# Altium schematic sheet RTC_Breakout_SP24.SchDoc
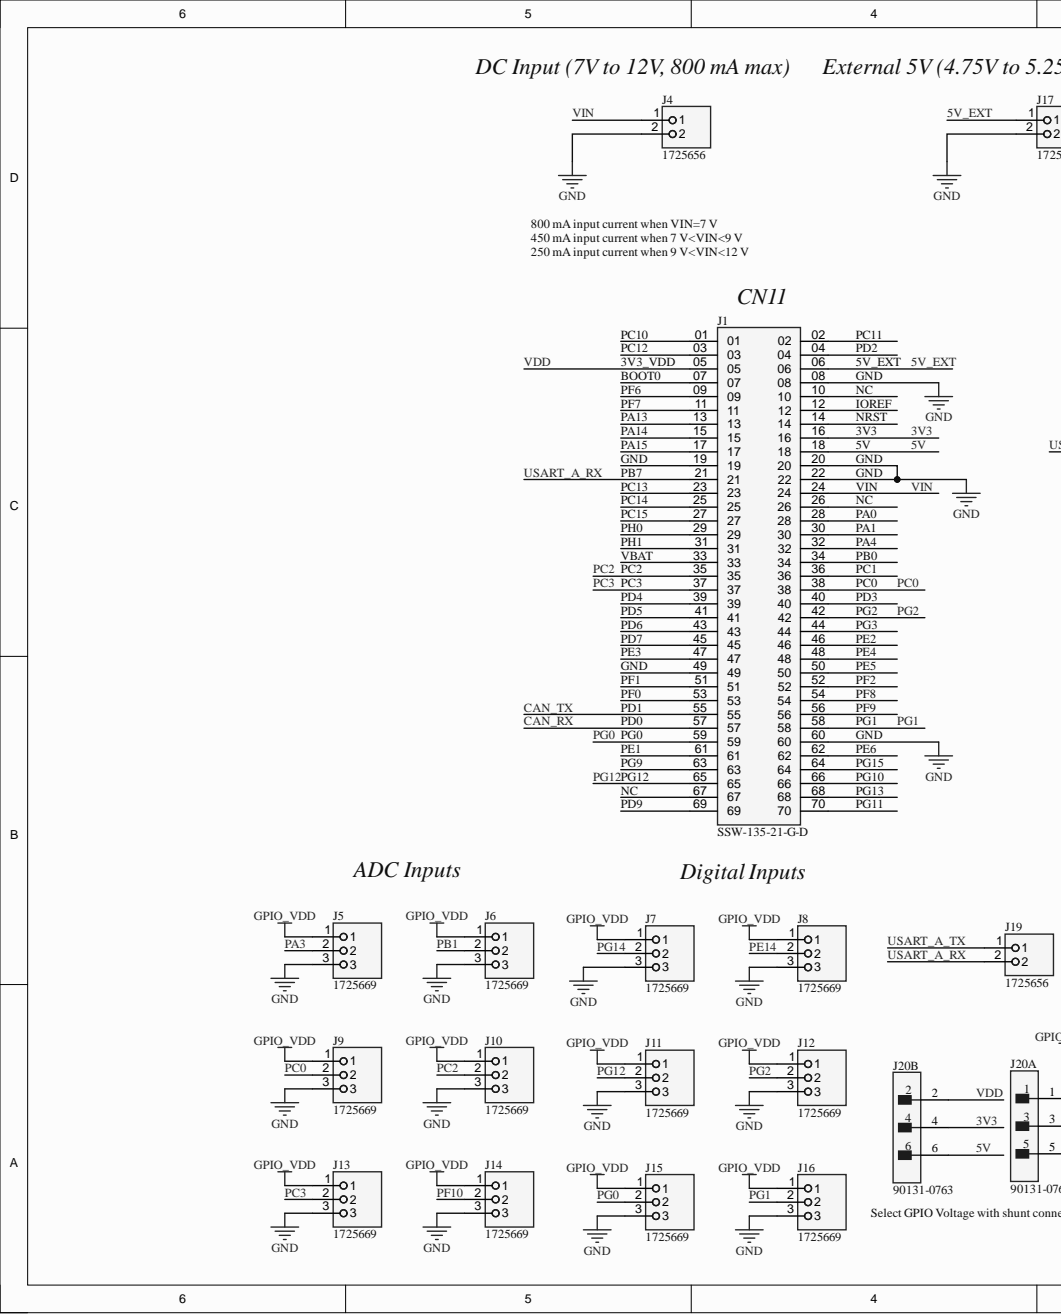
[diagram p0: RTC_Breakout_SP24.SchDoc, left]
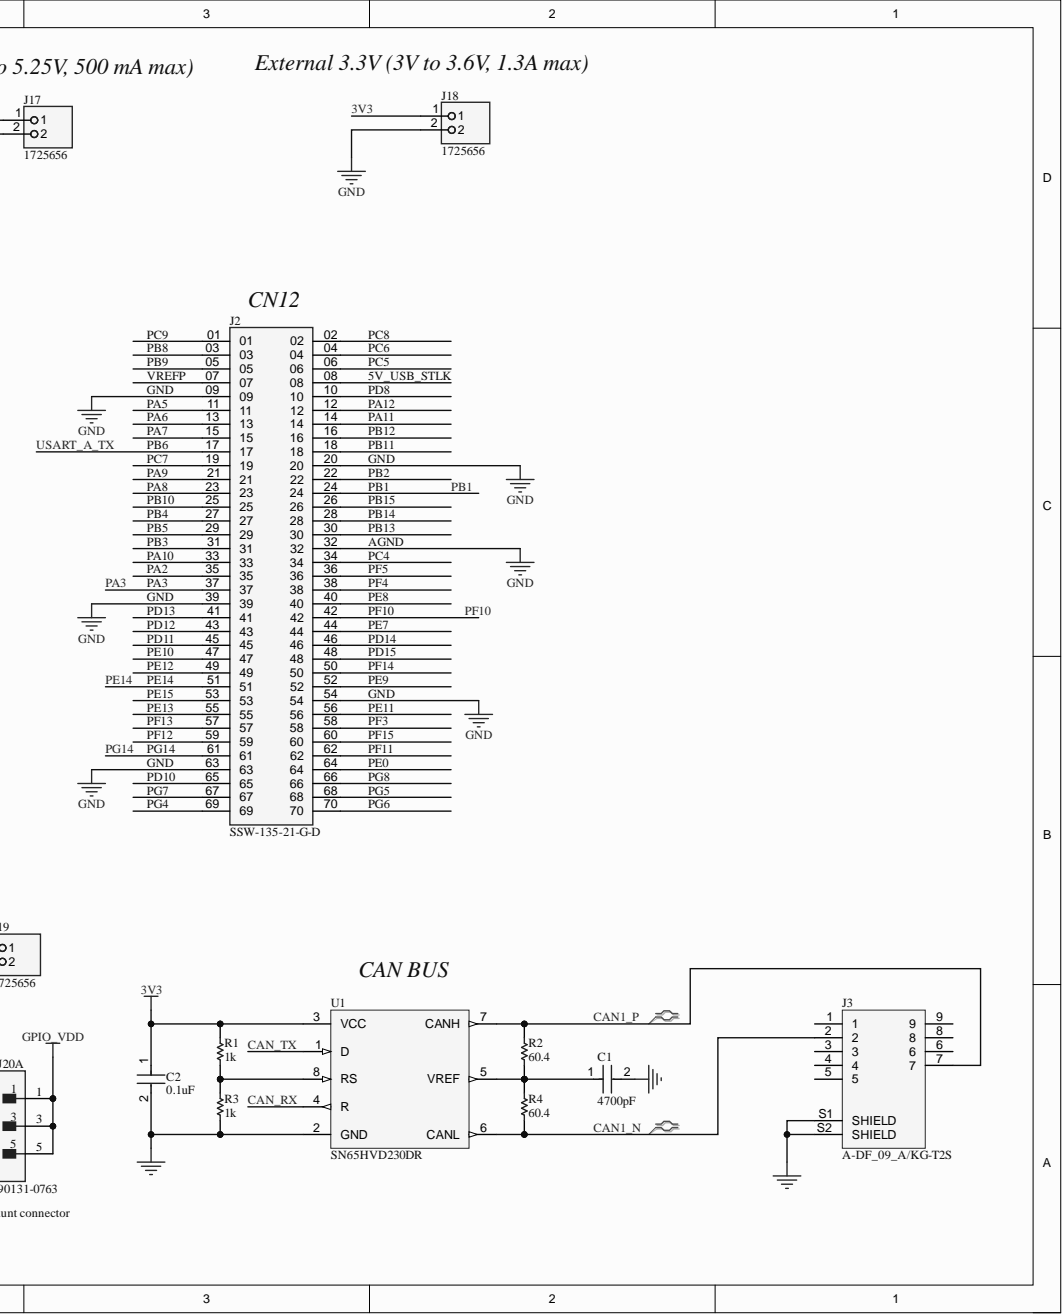
[diagram p1: RTC_Breakout_SP24.SchDoc, right]

## Parts
C1  4700pF  [FP-CC0805-0_85-MFG]
C2  0.1uF  [FP-CC0805-0_85-MFG]
J1  SSW-135-21-G-D  [SAMTEC_SSW-135-21-G-D]
J2  SSW-135-21-G-D  [SAMTEC_SSW-135-21-G-D]
J3  A-DF_09_A/KG-T2S  [ASSMANN_A-DF09A/KG-T2S]
J4  1725656  [PHOENIX_1725656]
J5  1725669  [PHOENIX_1725669]
J6  1725669  [PHOENIX_1725669]
J7  1725669  [PHOENIX_1725669]
J8  1725669  [PHOENIX_1725669]
J9  1725669  [PHOENIX_1725669]
J10  1725669  [PHOENIX_1725669]
J11  1725669  [PHOENIX_1725669]
J12  1725669  [PHOENIX_1725669]
J13  1725669  [PHOENIX_1725669]
J14  1725669  [PHOENIX_1725669]
J15  1725669  [PHOENIX_1725669]
J16  1725669  [PHOENIX_1725669]
J17  1725656  [PHOENIX_1725656]
J18  1725656  [PHOENIX_1725656]
J19  1725656  [PHOENIX_1725656]
J20  90131-0763  [FP-90131-0763-MFG]  (parts A,B)
R1  1k  [RESC2013X60X35ML10T20]
R2  60.4  [RESC2013X60X35LL10T20]
R3  1k  [RESC2013X60X35ML10T20]
R4  60.4  [RESC2013X60X35LL10T20]
U1  SN65HVD230DR  [SOIC127P599X175-8N]

## Nets
GND: C1.2 C2.2 J1.08 J1.20 J1.22 J1.60 J2.09 J2.20 J2.32 J2.39 J2.54 J2.63 J3.S1(SHIELD) J3.S2(SHIELD) J4.2 J5.3 J6.3 J7.3 J8.3 J9.3 J10.3 J11.3 J12.3 J13.3 J14.3 J15.3 J16.3 J17.2 J18.2 R3.1 U1.2(GND)
GPIO_VDD: J5.1 J6.1 J7.1 J8.1 J9.1 J10.1 J11.1 J12.1 J13.1 J14.1 J15.1 J16.1 J20.1 J20.3 J20.5
3V3: C2.1 J1.16 J18.1 J20.4 R1.2 U1.3(VCC)
5V: J1.18 J20.6
5V_EXT: J1.06 J17.1
CAN1_N: J3.2 R4.1 U1.6(CANL)
CAN1_P: J3.7 R2.2 U1.7(CANH)
CAN_RX: J1.57 U1.4(R)
CAN_TX: J1.55 U1.1(D)
PA3: J2.37 J5.2
PB1: J2.24 J6.2
PC0: J1.38 J9.2
PC2: J1.35 J10.2
PC3: J1.37 J13.2
PE14: J2.51 J8.2
PF10: J2.42 J14.2
PG0: J1.59 J15.2
PG1: J1.58 J16.2
PG2: J1.42 J12.2
PG12: J1.65 J11.2
PG14: J2.61 J7.2
USART_A_RX: J1.21 J19.2
USART_A_TX: J2.17 J19.1
VDD: J1.05 J20.2
VIN: J1.24 J4.1
NetC1_1: C1.1 R2.1 R4.2 U1.5(VREF)
NetR1_1: R1.1 R3.2 U1.8(RS)

Not connected: J1 01 02 03 04 07 09 10 11 12 13 14 15 17 19 23 25 26 27 28 29 30 31 32 33 34 36 39 40 41 43 44 45 46 47 48 49 50 51 52 53 54 56 61 62 63 64 66 67 68 69 70; J2 01 02 03 04 05 06 07 08 10 11 12 13 14 15 16 18 19 21 22 23 25 26 27 28 29 30 31 33 34 35 36 38 40 41 43 44 45 46 47 48 49 50 52 53 55 56 57 58 59 60 62 64 65 66 67 68 69 70; J3 1 3 4 5 6 8 9

## Library data of the parts
C1 = CMP-1036-03591-2: CAP CER 4700PF 50V X7R 0805; Manufacturer: Yageo; Manufacturer Part Number: CC0805KRX7R9BB472; Capacitance: 4.7 nF; Material: Ceramic; Tolerance: 0.1; Value: 4.7 nF; Automotive: No; Category: Cap; Component Kind: Standard; ComponentLink2Description: Datasheet; ComponentLink2URL: http://www.yageo.com/documents/recent/UPY-GPHC_Y5V_6.3V-to-50V_8.pdf; Device Class L1: Passive Components; Device Class L2: Capacitors; Device Class L3: Ceramic Capacitors; Digikey Description: CAP CER 4700PF 50V X7R 0805; Footprint Url: http://www.yageo.com/exep/pages/download/literatures/UPY-C_GEN_24.pdf; Height: 0.95mm; Ipc Land Pattern Name: CAPC200125X85; Lead Free: Yes; Library Name: Altium Content Vault; Mouser Description: Multilayer Ceramic Capacitors MLCC - SMD/SMT 4.7nF 50V X7R 10%; Octopart: https://octopart.com/cc0805krx7r9bb472-yageo-192986; Package: CC0805-0.85; RoHS: TRUE; Temperature: -30°C to +85°C; Temperature Characteristic: X7R; Temperature Range High: +85°C; Temperature Range Low: -30°C; Voltage Rating: 50 V
C2 = CMP-2100-03606-3: CAP CER 0.1UF 50V X7R 0805; Manufacturer: Yageo; Manufacturer Part Number: CC0805KRX7R9BB104; Capacitance: 100 nF; Material: Ceramic; Tolerance: 0.1; Value: 100 nF; Automotive: No; Category: Cap; Component Kind: Standard; ComponentLink2Description: Datasheet; ComponentLink2URL: http://www.yageo.com/documents/recent/UPY-GPHC_Y5V_6.3V-to-50V_8.pdf; Device Class L1: Passive Components; Device Class L2: Capacitors; Device Class L3: Ceramic Capacitors; Digikey Description: CAP CER 0.1UF 50V X7R 0805; Footprint Url: http://www.yageo.com/exep/pages/download/literatures/UPY-C_GEN_24.pdf; Height: 0.95mm; Ipc Land Pattern Name: CAPC200125X85; Lead Free: Yes; Library Name: Altium Content Vault; Mouser Description: Multilayer Ceramic Capacitors MLCC - SMD/SMT 100nF 50V X7R 10%; Octopart: https://octopart.com/cc0805krx7r9bb104-yageo-192993; Package: CC0805-0.85; RoHS: TRUE; Temperature: -30°C to +85°C; Temperature Characteristic: X7R; Temperature Range High: +85°C; Temperature Range Low: -30°C; Voltage Rating: 50 V
J1 J2 = SSW-135-21-G-D: MF: {'manufacturer': u'Samtec Inc.'}; Availability: Unavailable; Component Kind: Standard; Library Name: SSW-135-21-G-D.SchLib; MP: SSW-135-21-G-D; Package: None; Price: None
J3 = A-DF_09_A/KG-T2S: 9 Position D-Sub Receptacle, Female Sockets Connector; MF: Assmann WSW; MP: A-DF 09 A/KG-T2S; Availability: Unavailable; Check_prices: https://www.snapeda.com/parts/A-DF%2009%20A/KG-T2S/Assmann%20WSW%20Components/view-part/2761262/?ref=eda; Component Kind: Standard; Package: None; Price: None; SnapEDA_Link: https://www.snapeda.com/parts/A-DF%2009%20A/KG-T2S/Assmann%20WSW%20Components/view-part/2761262/?ref=snap; Library Name: ertc_symbols.SchLib
J4 J17-J19 = 1725656: PCB Terminal Screw 2.54mm 20AWG 160V 6A 2 Position COMBICON MPT Series | Phoenix Contact 1725656; Check_prices: https://www.snapeda.com/parts/1725656/Phoenix+Contact/view-part/?ref=eda; Component Kind: Standard; Library Name: 1725656.SchLib; MF: Phoenix Contact; MP: 1725656; Package: None; SnapEDA_Link: https://www.snapeda.com/parts/1725656/Phoenix+Contact/view-part/?ref=snap
J5-J16 = 1725669: Vis de borne PCB 2,54 mm 20AWG 160V 6A 3 positions COMBICON MPT Series | PhÃ©nix Contacter 1725669; MF: Phoenix Contact; Check_prices: https://www.snapeda.com/parts/1725669/Phoenix+Contact/view-part/?ref=eda; Component Kind: Standard; Library Name: 1725669.SchLib; MP: 1725669; Package: None; SnapEDA_Link: https://www.snapeda.com/parts/1725669/Phoenix+Contact/view-part/?ref=snap
J20 = CMP-00257-00655468-1: 90131-0763 Conn Unshrouded Header HDR 6 POS 2.54mm Solder ST Top Entry Thru-Hole C-Grid III™ Tray; Manufacturer Lifecycle Status: ACTIVE; NexarPartId: 655468; Contact Resistance: 20mΩ; Current Rating: 3A; Gender: Male; Insulation Resistance: 5GΩ; Number of Circuits: 6; Plating: Tin; Voltage Rating: 350V; Component Kind: Standard; Contact Material: Brass; Contact Plating: Gold; Country of Origin: SG; Height: 9.65mm; Housing Color: Black; Housing Material: Polyester; Lead Free: Lead Free; Lead Pitch: 2.54mm; Library Name: Altium Content Vault; Lifecycle Status: Production; Material: Glass, Polyester; Max Operating Temperature: 125°C; Min Operating Temperature: -55°C; Mount: Through Hole; Number of Contacts: 6; Number of Poles: 6; Number of Positions: 6; Number of Rows: 2; Orientation: Vertical; Pitch: 2.54mm; Radiation Hardening: No; REACH SVHC: Unknown; RoHS: Compliant; Schedule B: 8536694040; Termination: Through Hole; Voltage Rating (AC): 350V; Voltage Rating (DC): 350V; Working Voltage: 350V
R1 R3 = CMP-2100-03671-1: Chip Resistor, 1 KOhm, +/- 1%, 125 mW, -55 to 155 degC, 0805 (2012 Metric), RoHS, Tape and Reel; Manufacturer: Yageo; Max Power Dissipation: 125mW; Resistance: 1KOhm; Temperature Coefficient: 100ppm/degreesC; Voltage Rating: 150V; Case Code (Imperial): 0805; Case Code (Metric): 2012; Case/Package: 0805; Component Kind: Standard; Composition: ThickFilm; Datasheet URL: http://www.yageo.com/NewPortal/yageodocoutput?fileName=/pdf/R-Chip/PYu-RC_Group_51_RoHS_L_5.pdf; Datasheet Version: Rev. 5, 10/2016; Depth: 1.25mm; Height: 0.024inch; Lead Free: LeadFree; Length: 0.079inch; Library Name: Altium Content Vault; Manufacturer URL: http://www.yageo.com/; Max Operating Temperature: 155degC; Military Standard: Not; Min Operating Temperature: -55degC; Number of Pins: 2; Number of Terminations: 2; Package Description: 2-Pin Surface Mounted Device, Body 2 x 1.25 mm; Package Quantity: 1; Package Reference: 0805; Packaging: TapeandReel; Power Rating: 125mW; REACH SVHC: Unknown; RoHSCompliant: true; Termination: SMD/SMT; Tolerance: 1%; Voltage Rating (DC): 150V; Width: 0.049inch
R2 R4 = CMP-2001-01223-1: Manufacturer: Yageo; Part Number: RC0805FR-0760R4L; Component Kind: Standard; Library Name: Altium Content Vault
U1 = SN65HVD230DR: 3.3 V CAN Transceiver with Standby Mode 8-SOIC -40 to 85; MF: Texas Instruments; Arrow_Electronics-Purchase-URL: https://snapeda.com/shop?store=Arrow+Electronics&id=51767; Availability: Good; Component Kind: Standard; DigiKey-Purchase-URL: https://snapeda.com/shop?store=DigiKey&id=51767; Mouser-Purchase-URL: https://snapeda.com/shop?store=Mouser&id=51767; MP: SN65HVD230DR; Package: SOIC-8 Texas Instruments; Price: None; SnapEDA_Link: https://www.snapeda.com/parts/SN65HVD230DR/Texas%20Instruments/view-part/51767/?ref=snap; Texas_Instruments-Purchase-URL: https://snapeda.com/shop?store=Texas+Instruments&id=51767; Library Name: ertc_symbols.SchLib
